# Altium schematic sheet Connect_2.SchDoc(Connect_2)
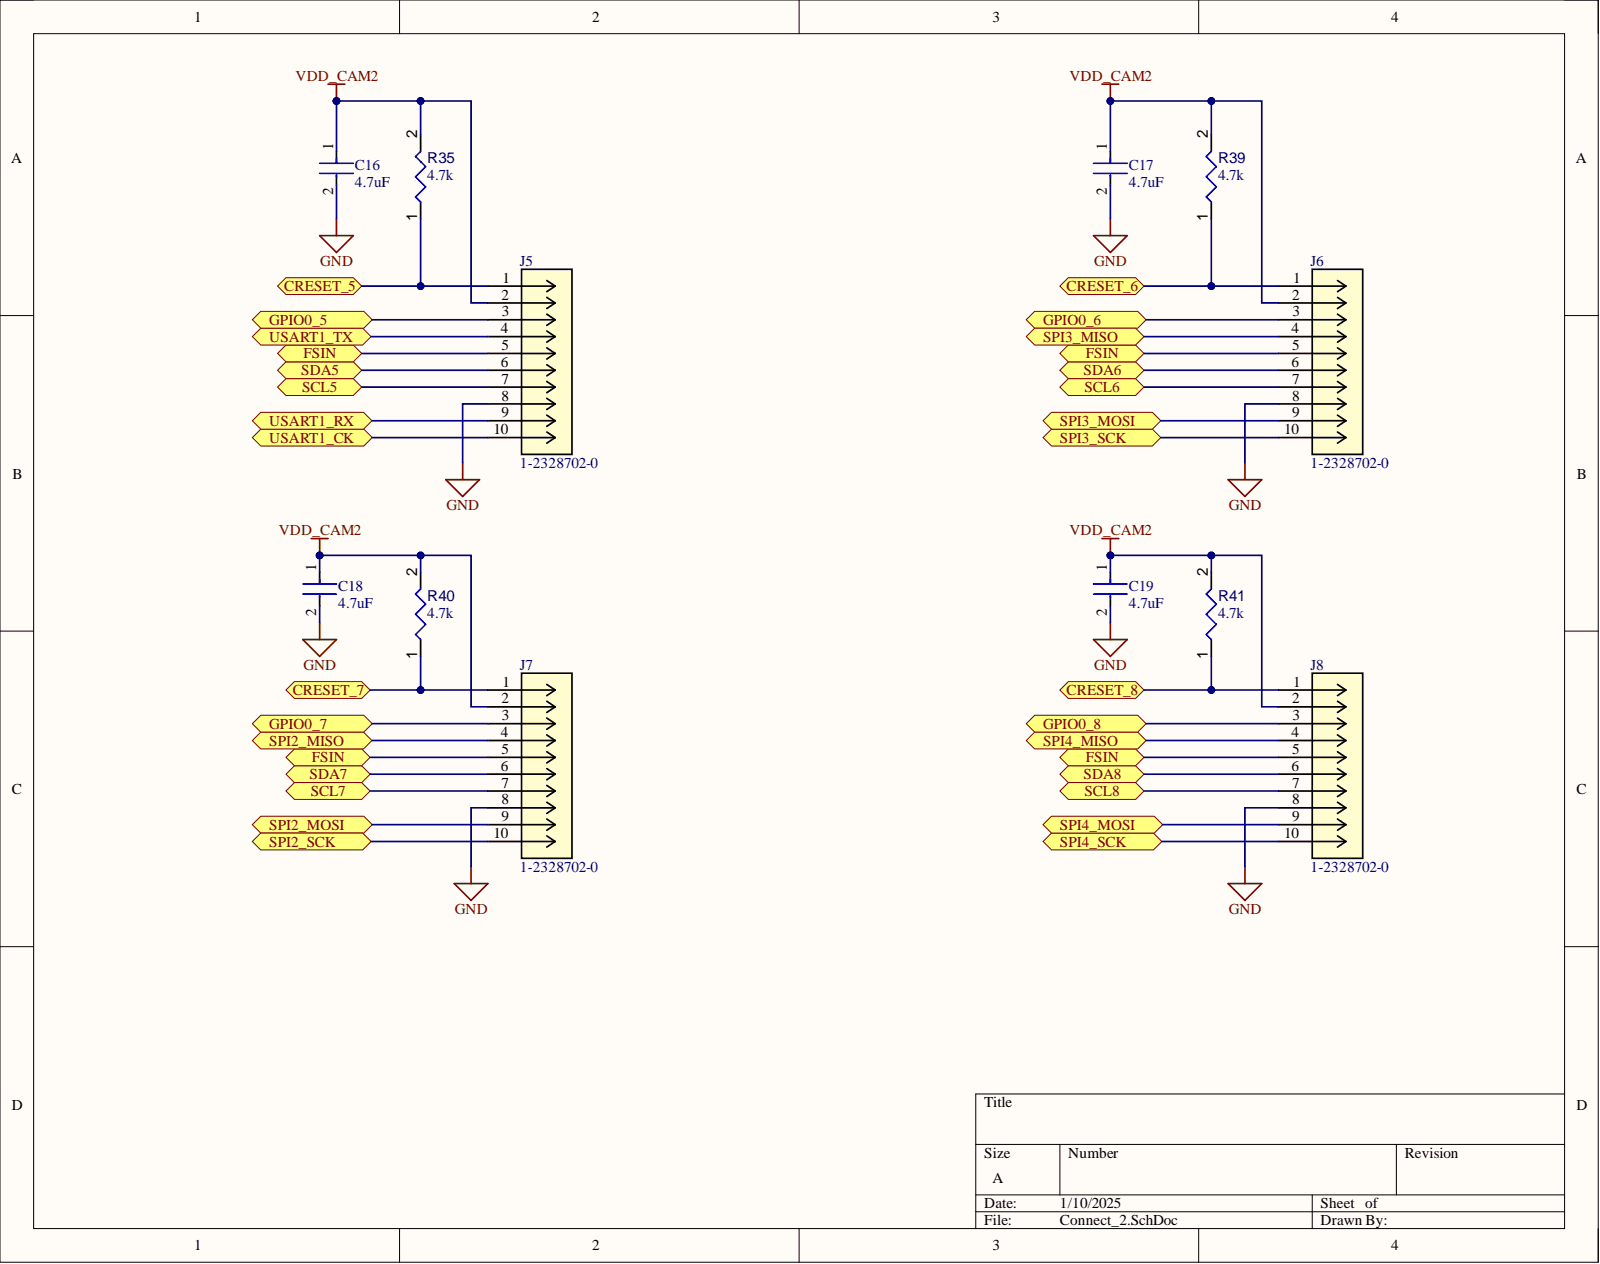
[diagram p0: Connect_2.SchDoc]

## Parts
C16  4.7uF
C17  4.7uF
C18  4.7uF
C19  4.7uF
J5  1-2328702-0
J6  1-2328702-0
J7  1-2328702-0
J8  1-2328702-0
R35  value 4.7k  (hidden comment: Resistor 4K7  +/-1 % 0402 63 mW)
R39  value 4.7k  (hidden comment: Resistor 4K7  +/-1 % 0402 63 mW)
R40  value 4.7k  (hidden comment: Resistor 4K7  +/-1 % 0402 63 mW)
R41  value 4.7k  (hidden comment: Resistor 4K7  +/-1 % 0402 63 mW)

## Ports
CRESET_5, CRESET_6, CRESET_7, CRESET_8, FSIN, GPIO0_5, GPIO0_6, GPIO0_7, GPIO0_8, SCL5, SCL6, SCL7, SCL8, SDA5, SDA6, SDA7, SDA8, SPI2_MISO, SPI2_MOSI, SPI2_SCK, SPI3_MISO, SPI3_MOSI, SPI3_SCK, SPI4_MISO, SPI4_MOSI, SPI4_SCK, USART1_CK, USART1_RX, USART1_TX

## Nets
GND: C16.2 C17.2 C18.2 C19.2 J5.8 J6.8 J7.8 J8.8
VDD_CAM2: C16.1 C17.1 C18.1 C19.1 J5.2 J6.2 J7.2 J8.2 R35.2 R39.2 R40.2 R41.2
CRESET_5: J5.1 R35.1 ; port CRESET_5
CRESET_6: J6.1 R39.1 ; port CRESET_6
CRESET_7: J7.1 R40.1 ; port CRESET_7
CRESET_8: J8.1 R41.1 ; port CRESET_8
FSIN: J5.5 J6.5 J7.5 J8.5 ; port FSIN
GPIO0_5: J5.3 ; port GPIO0_5
GPIO0_6: J6.3 ; port GPIO0_6
GPIO0_7: J7.3 ; port GPIO0_7
GPIO0_8: J8.3 ; port GPIO0_8
SCL5: J5.7 ; port SCL5
SCL6: J6.7 ; port SCL6
SCL7: J7.7 ; port SCL7
SCL8: J8.7 ; port SCL8
SDA5: J5.6 ; port SDA5
SDA6: J6.6 ; port SDA6
SDA7: J7.6 ; port SDA7
SDA8: J8.6 ; port SDA8
SPI2_MISO: J7.4 ; port SPI2_MISO
SPI2_MOSI: J7.9 ; port SPI2_MOSI
SPI2_SCK: J7.10 ; port SPI2_SCK
SPI3_MISO: J6.4 ; port SPI3_MISO
SPI3_MOSI: J6.9 ; port SPI3_MOSI
SPI3_SCK: J6.10 ; port SPI3_SCK
SPI4_MISO: J8.4 ; port SPI4_MISO
SPI4_MOSI: J8.9 ; port SPI4_MOSI
SPI4_SCK: J8.10 ; port SPI4_SCK
USART1_CK: J5.10 ; port USART1_CK
USART1_RX: J5.9 ; port USART1_RX
USART1_TX: J5.4 ; port USART1_TX

## Library data of the parts
C16-C19 = CMP-2000-05997-2: CAP CER 4.7UF 10V X5R 0402; Dielectric Material: Ceramic; Tolerance: 10%; Capacitance: 4.7uF; Case Code (Imperial): 0402; Case Code (Metric): 1005; Case/Package: 0402; Component Kind: Standard; Dielectric: X5R; Lead Free: Lead Free; Length: 0.039inch; Library Name: Altium Content Vault; Max Operating Temperature: 85°C; Min Operating Temperature: -55°C; Mount: Surface Mount; Package Quantity: 10000; Packaging: Cut Tape; REACH SVHC: No SVHC; RoHS Compliant: Yes; Series: C; Thickness: 0.022inch; Voltage Rating: 10V; Voltage Rating (DC): 10V; Width: 0.02inch
J5-J8 = 1-2328702-0: No Description Available; Mfr_Name: TE Connectivity; Component Kind: Standard; Library Name: 1-2328702-0.SchLib; RefDes: RefDes; Type: Type
R35 R39-R41 = CMP-009-00158-3: Chip Resistor, 4,7 kOhms, +/-1 %, 63 mW, -55 to 155 degC, 0402; Power: 63mW; Tolerance: 1%; Case/Package: 0402; Component Kind: Standard; Max Operating Temperature: 155°C; Min Operating Temperature: -55°C; Pins: 2; Library Name: Openwater
